# Altium schematic sheet LiPo_Battery_Charger.SchDoc(LiPo_Battery_Charger)
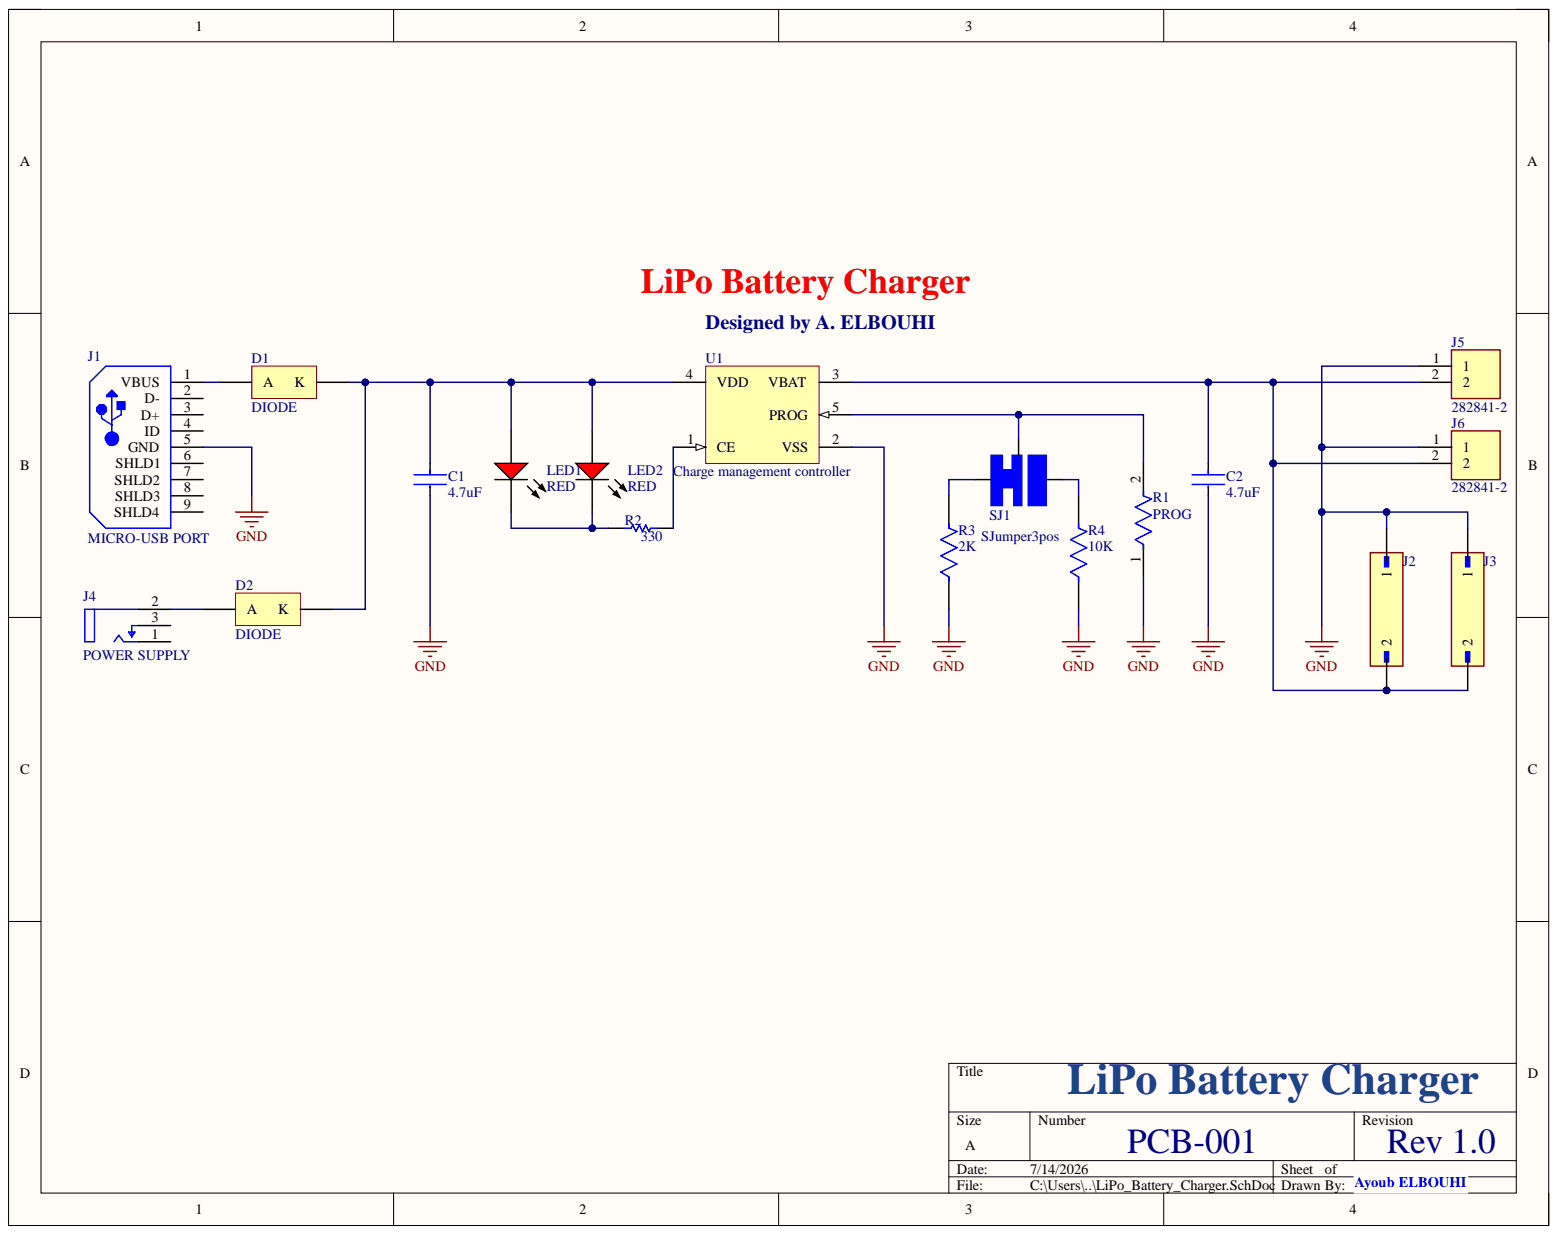
[diagram p0: LiPo_Battery_Charger.SchDoc]

## Parts
C1  4.7uF  [FP-GRM155-0_15-IPC_C]
C2  4.7uF  [FP-GRM155-0_15-IPC_C]
D1  DIODE  [ONSC-SMA-2-403D-02_V]
D2  DIODE  [ONSC-SMA-2-403D-02_V]
J1  MICRO-USB PORT  [FCI-10104110-0001LF_V]
J2  67997-402HLF  [AMPHENOL_67997-402HLF]  (value not drawn)
J3  67997-402HLF  [AMPHENOL_67997-402HLF]  (value not drawn)
J4  POWER SUPPLY  [FP-RASM722PTR13X-MFG]
J5  282841-2  [TE_282841-2]
J6  282841-2  [TE_282841-2]
LED1  RED  [INDPR60-254-520X380X650]
LED2  RED  [INDPR60-254-520X380X650]
R1  PROG  [FP-CF18-MFG]
R2  330  [RESC3225X60X45ML20T20]
R3  2K  [FP-CR0603-IPC_B]
R4  10K  [FP-TFCR0805-IPC_B]
SJ1  SJumper3pos  [PCBComponent_1]
U1  Charge management controller  [SOT-23-OT5_M]

## Nets
GND: C1.2 C2.2 J1.5(GND) J2.1 J3.1 J5.1 J6.1 R1.1 R3.1 R4.1 U1.2(VSS)
NetC1_1: C1.1 D1.1 D2.1 LED1.1 LED2.1 U1.4(VDD)
NetC2_1: C2.1 J2.2 J3.2 J5.2 J6.2 U1.3(VBAT)
NetD1_2: D1.2 J1.1(VBUS)
NetD2_2: D2.2 J4.2
NetLED1_2: LED1.2 LED2.2 R2.1
NetR1_2: R1.2 SJ1.2 U1.5(PROG)
NetR2_2: R2.2 U1.1(CE)
NetR3_2: R3.2 SJ1.1
NetR4_2: R4.2 SJ1.3

Not connected: J1 2(D-) 3(D+) 4(ID) 6(SHLD1) 7(SHLD2) 8(SHLD3) 9(SHLD4); J4 1 3

## Library data of the parts
C1 C2 = CMP-06035-015349-1: Chip Multilayer Ceramic Capacitors for General Purpose, 0402, 4.7uF, X6S, 22%, 20%, 6.3V; Capacitance: 4.7uF; Component Kind: Standard; Dielectric: X6S; Length: 1mm; Library Name: Altium Content Vault; Thickness: 500um; Tolerance: 20%; Voltage Rating (DC): 6.3V; Width: 500um
D1 D2 = CMP-1055-00320-1: Schottky Power Rectifier, 2-Pin SMA, Pb-Free, Tape and Reel; Manufacturer: On Semiconductor; PartNumber: MBRA140T3G; Configuration: Single; IFSM Max (A): 30; Component Kind: Standard; ComponentLink1Description: Manufacturer URL; ComponentLink1URL: http://www.onsemi.com/; ComponentLink2Description: Datasheet; ComponentLink2URL: http://www.onsemi.cn/pub_link/Collateral/MBRA140T3-D.PDF; ComponentLink3Description: Package Specification; ComponentLink3URL: http://www.onsemi.com/pub_link/Collateral/403D-02.PDF; DatasheetVersion: Rev. 10; Library Name: Altium Content Vault; Mounting Technology: Surface Mount; PackageDescription: 2-Pin Small Molded Package, J-Bend Lead, Body 2.605 x 4.315 mm; PackageReference: SMA-2-403D-02; PackageVersion: Rev. G, 07/2012; Packing: Tape and Reel; RoHS: TRUE
J1 = CMP-2000-05823-1: Micro USB, Receptacle, -55 to 85 degC, 5-Pin SMD, RoHS, Tape and Reel; Manufacturer: FCI Electronics; Connector Mounting: PCB; Connector Type: USBMicroB; Contact Termination: SurfaceMountRightAngle; No. of Contacts: 5; Component Kind: Standard; Contact Plating: Gold; Datasheet URL: http://portal.fciconnect.com/Comergent//fci/drawing/10104110.pdf; Datasheet Version: Rev. C, 03/2011; Gender: Receptacle; Library Name: Altium Content Vault; Manufacturer URL: http://portal.fciconnect.com/; Mounting Technology: SurfaceMount; Package Description: 5-Pin Surface Mount Device, Body 8.06 x 5.6 mm, Pitch 0.65 mm; Package Reference: 10104110-0001LF
J2 J3 = 67997-402HLF: MF: {'manufacturer': u'Amphenol ICC (FCI)'}; Availability: Unavailable; Component Kind: Standard; Library Name: 67997-402HLF.SchLib; MP: 67997-402HLF; Package: None; Price: None
J4 = CMP-47995-000002-1: CONN PWR JACK 2.1X5.5MM SOLDER; Current Rating: 5A; Gender: Male; Shielding: Unshielded; Case/Package: SMD/SMT; Color: Black; Component Kind: Standard; Connector Type: Jack; Contact Material: Copper Alloy; Contact Plating: Tin; Housing Color: Black; Housing Material: Thermoplastic; Library Name: Altium Content Vault; Mount: Surface Mount; Package Quantity: 120; Termination: Solder
J5 J6 = 282841-2: Conn Terminal Block 2 POS 5.08mm Solder ST Thru-Hole 17.5A/Contact; MF: TE Connectivity; Availability: In Stock; Check_prices: https://www.snapeda.com/parts/282841-2/TE+Connectivity+AMP+Connectors/view-part/?ref=eda; Component Kind: Standard; EU_RoHS_Compliance: Compliant with Exemptions; Library Name: 282841-2.SchLib; MP: 282841-2; Package: None; Price: None; Purchase-URL: https://pricing.snapeda.com/search/part/282841-2/?ref=eda; SnapEDA_Link: https://www.snapeda.com/parts/282841-2/TE+Connectivity+AMP+Connectors/view-part/?ref=snap
LED1 LED2 = CMP-1674-00005-1: Radial LED, 12.6 mcd, 20 mA, 2 V, -55 to 100 degC, 2-Pin THD, RoHS, Bulk; Current Rating: 3.6 A; Resistance: 0.045 Ohm; Shielding: Unshielded; Tolerance: 20%; Case\Package: Radial; Component Kind: Standard; ComponentLink1Description: Manufacturer URL; ComponentLink1URL: http://www.us.liteon.com/; ComponentLink2Description: Datasheet; ComponentLink2URL: https://datasheet.ciiva.com/15117/getdatasheetpartid-187746-15117985.pdf; DatasheetVersion: Dec-03; Height: 1.1 inch; Lead Pitch: 11.44 mm; Length: 0.97 inch; Library Name: Altium Content Vault; Max Operating Temperature: 130 degC; Min Operating Temperature: -40 degC; Mount: Through Hole; Package Quantity: 55; PackageDescription: 2-Pin Through Hole Device, Dia 3.8 mm, Pitch 2.54 mm; PackageReference: LTL-1CHE; Packaging: Tray; Radiation Hardening: No; RoHSCompliant: true; Width: 0.62 inch
R1 = CMP-26528-000002-1: RES 10K OHM 1/8W 5% CF AXIAL; Manufacturer: Stackpole Electronics; Manufacturer Part Number: CF18JT10K0; Power Rating: 0.125W; Resistance: 10kΩ; Temperature Coefficient: 0-500ppm/°C; Value: 10kΩ; Voltage Rating: 250V; Automotive: No; Category: Res; Component Kind: Standard; ComponentLink2Description: Datasheet; ComponentLink2URL: http://www.seielect.com/Catalog/SEI-CF_CFM.pdf; Composition: Carbon Film; Device Class L1: Passive Components; Device Class L2: Resistors; Device Class L3: Through-Hole Resistors; Digikey Description: RES 10K OHM 1/8W 5% CF AXIAL; Height: 2mm; Ipc Land Pattern Name: RESAD656W65L360D200; Lead Free: Yes; Library Name: Altium Content Vault; Octopart: https://octopart.com/cf18jt10k0-stackpole+electronics-19205642; Package: CF18; RoHS: TRUE; Series: CF; Temperature: -55°C to +155°C; Temperature Range High: +155°C; Temperature Range Low: -55°C; Tolerance: 5%
R2 = CMP-2005-00707-1: Manufacturer: Vishay Dale; Part Number: CRCW1210330RJNTA; Component Kind: Standard; Library Name: Altium Content Vault
R3 = CMP-07231-005094-1: Thick Film Chip Resistors 0603 2kΩ 0.1W 5% 200ppm/°C; Max Power Dissipation: 100mW; Resistance: 2kR; Voltage Rating: 50V; Case Code (Imperial): 0603; Case Code (Metric): 1608; Case/Package: 0603; Component Kind: Standard; Composition: Thick Film; Depth: 0.8mm; Features: Moisture Resistant; Height: 0.022inch; Length: 0.063inch; Library Name: Altium Content Vault; Max Operating Temperature: 155°C; Military Standard: Not; Min Operating Temperature: -55°C; Mount: Surface Mount; Number of Terminations: 2; Package Quantity: 10000; Packaging: Tape and Reel; Power Rating: 100mW; Temperature Coefficient: 200ppm/°C; Termination: SMD/SMT; Thickness: 457um; Tolerance: 5%; Width: 0.031inch
R4 = CMP-250906-173936-1: RES Thin Film,  10kΩ, 0.1%, 0.1W, 50ppm/°C, 0805; Component Kind: Standard; Library Name: Altium Content Vault
SJ1 = Solder_jumper_3pos: solder jumper 3 pins; Component Kind: Standard; Library Name: solder_jumper_3posSchLib.SchLib
U1 = CMP-0133-00141-1: Simple, Miniature Single-Cell, Fully Integrated Li-Ion/Li-Polymer Charge Management Controller, 4.2V, 5-Pin SOT-23, Industrial Temperature, Tape and Reel; Code_IPC: SOT23-5; Component Kind: Standard; DatasheetVersion: revB, Sep-2007; Library Name: Altium Content Vault; PackageDescription: 5-Lead Plastic Small Outline Transistor (OT) [SOT-23]; PackageReference: SOT-23-OT5; PackageVersion: revBB, Aug-2009
